# Altium schematic sheet tranciever.SchDoc
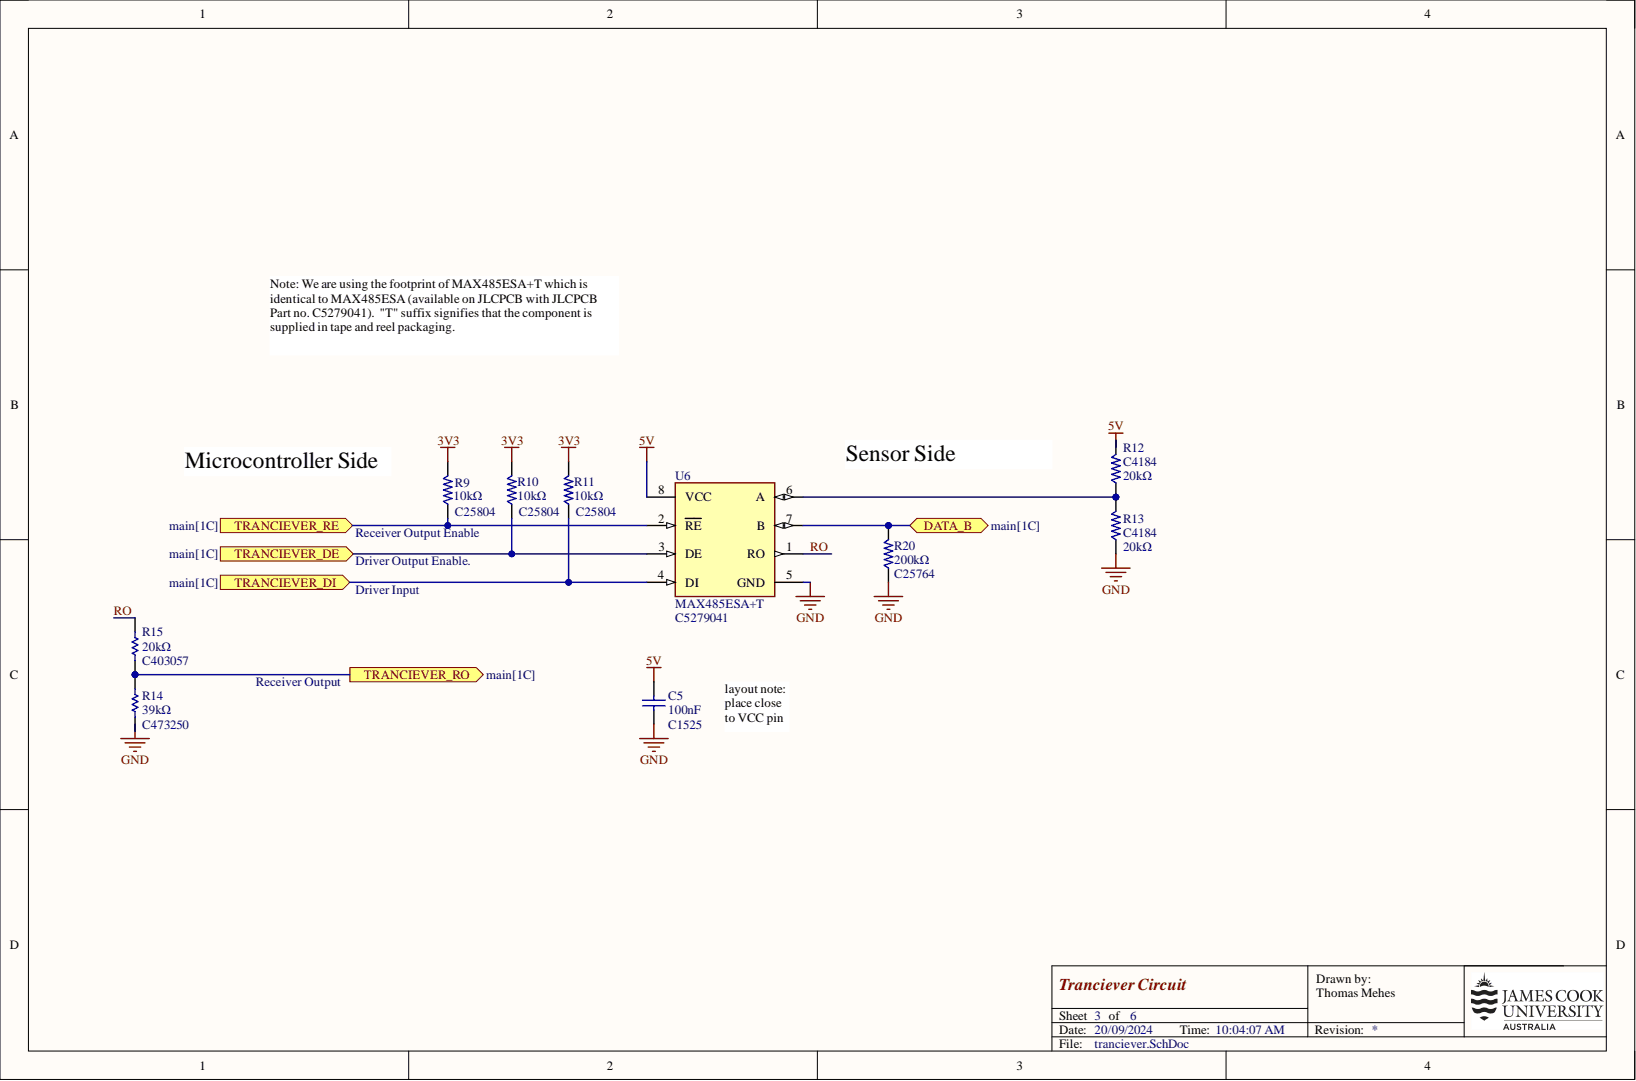
[diagram p0: tranciever.SchDoc]

## Parts
C5  Capacitance: 100nF  JLCPCB Part: C1525  [CAPC1050X55X23NL05T13]  (hidden comment: CL05B104KO5NNNC)
R9  JLCPCB Part: C25804  Resistance: 10kΩ  [RES-0603]  (hidden comment: 0603WAF1002T5E)
R10  JLCPCB Part: C25804  Resistance: 10kΩ  [RES-0603]  (hidden comment: 0603WAF1002T5E)
R11  JLCPCB Part: C25804  Resistance: 10kΩ  [RES-0603]  (hidden comment: 0603WAF1002T5E)
R12  JLCPCB Part: C4184  Resistance: 20kΩ  [RES-0603]  (hidden comment: 0603WAF2002T5E)
R13  JLCPCB Part: C4184  Resistance: 20kΩ  [RES-0603]  (hidden comment: 0603WAF2002T5E)
R14  JLCPCB Part: C473250  Resistance: 39kΩ  [RESC1608X55X30LL20T20]  (hidden comment: ERA-3AEB393V)
R15  JLCPCB Part: C403057  Resistance: 20kΩ  [RESC1608X55X30ML15T15]  (hidden comment: ERJ-3EKF2002V)
R20  JLCPCB Part: C25764  Resistance: 200kΩ  [RES-0402]  (hidden comment: 0402WGF2003TCE)
U6  MAX485ESA+T  JLCPCB Part: C5279041  [FP-S8-2-MFG]

## Ports
DATA_B, TRANCIEVER_DE, TRANCIEVER_DI, TRANCIEVER_RE, TRANCIEVER_RO

## Nets
3V3: R9.2 R10.2 R11.2
5V: C5.2 R12.2 U6.8(VCC)
GND: C5.1 R13.1 R14.1 R20.1 U6.5(GND)
DATA_B: R20.2 U6.7(B) ; port DATA_B
RO: R15.2 U6.1(RO)
TRANCIEVER_DE: R10.1 U6.3(DE) ; port TRANCIEVER_DE
TRANCIEVER_DI: R11.1 U6.4(DI) ; port TRANCIEVER_DI
TRANCIEVER_RE: R9.1 U6.2(RE) ; port TRANCIEVER_RE
TRANCIEVER_RO: R14.2 R15.1 ; port TRANCIEVER_RO
NetR12_1: R12.1 R13.2 U6.6(A)

## Library data of the parts
C5 = CMP-00007-3: Chip Capacitor, 100 nF, +/- 10%, 16 V, -55 to 125 degC, 0402 (1005 Metric), RoHS, Tape and Reel; Manufacturer: Samsung Electro-Mechanics; Dielectric: X7R; Rated Voltage: 16V; Case Size/Dimension: 0402; Component Kind: Standard; Datasheet URL: https://datasheet.ciiva.com/29198/458718-da-01-en-vs-kondensator-0402-29198423.pdf; Datasheet Version: Nov-10; Manufacturer URL: http://www.samsungsem.com/global/index.jsp; Tolerance (%): ±10%; Library Name: James Cook University
R9-R11 = CMP-009-00072-1: Resistor, 10kΩ, ±1%, 100mW, 75V, ±100ppm/℃, -55℃~+155℃, SMD 0603; Manufacturer: Uniroyal; Category: Resistors; Component Kind: Standard; ComponentLink1Description: Datasheet; ComponentLink1URL: https://datasheet.lcsc.com/lcsc/2206010045_UNI-ROYAL-Uniroyal-Elec-0603WAF1002T5E_C25804.pdf; ComponentLink2Description: JLCPCB Part URL; ComponentLink2URL: https://jlcpcb.com/partdetail/C25804; Design Item ID: 0603WAF1002T5E; JLCPCB Basic Part: Yes; Manufacturer Part Number: 0603WAF1002T5E; Package Size: 0603; Power Rating: 100mW; Source: jlcpcb_resistors_basic.schlib; Temperature Coefficient: ±100ppm/℃; Temperature Rating: -55℃~+155℃; Tolerance: ±1%; Voltage Rating: 75V; Library Name: James Cook University
R12 R13 = CMP-009-00100-1: Resistor, 20kΩ, ±1%, 100mW, 75V, ±100ppm/℃, -55℃~+155℃, SMD 0603; Manufacturer: Uniroyal; Category: Resistors; Component Kind: Standard; ComponentLink1Description: Datasheet; ComponentLink1URL: https://datasheet.lcsc.com/lcsc/2206010230_UNI-ROYAL-Uniroyal-Elec-0603WAF2002T5E_C4184.pdf; ComponentLink2Description: JLCPCB Part URL; ComponentLink2URL: https://jlcpcb.com/partdetail/C4184; Design Item ID: 0603WAF2002T5E; JLCPCB Basic Part: Yes; Manufacturer Part Number: 0603WAF2002T5E; Package Size: 0603; Power Rating: 100mW; Source: jlcpcb_resistors_basic.schlib; Temperature Coefficient: ±100ppm/℃; Temperature Rating: -55℃~+155℃; Tolerance: ±1%; Voltage Rating: 75V; Library Name: James Cook University
  R12: JLCPCB Part Number: C4184
R14 = CMP-2000-00055-1: Manufacturer: Panasonic; Component Kind: Standard; Library Name: Altium Content Vault; Part Number: ERA-3AEB393V
R15 = CMP-2000-00318-1: Manufacturer: Panasonic; Component Kind: Standard; Library Name: Altium Content Vault; Part Number: ERJ-3EKF2002V
R20 = CMP-009-00025-1: Resistor, 200kΩ, ±1%, 62.5mW, 50V, ±100ppm/℃, -55℃~+155℃, SMD 0402; Manufacturer: Uniroyal; Category: Resistors; Component Kind: Standard; ComponentLink1Description: Datasheet; ComponentLink1URL: https://datasheet.lcsc.com/lcsc/2206010100_UNI-ROYAL-Uniroyal-Elec-0402WGF2003TCE_C25764.pdf; ComponentLink2Description: JLCPCB Part URL; ComponentLink2URL: https://jlcpcb.com/partdetail/C25764; Design Item ID: 0402WGF2003TCE; JLCPCB Basic Part: Yes; Manufacturer Part Number: 0402WGF2003TCE; Package Size: 0402; Power Rating: 62.5mW; Source: jlcpcb_resistors_basic.schlib; Temperature Coefficient: ±100ppm/℃; Temperature Rating: -55℃~+155℃; Tolerance: ±1%; Voltage Rating: 50V; Library Name: James Cook University
U6 = CMP-05679-000009-1: IC TRANSCEIVER HALF 1/1 8SOIC; Manufacturer: Maxim Integrated; Data Rate: 2.5Mbits/s; Interface: RS-485,RS-422; Max Supply Voltage: 5.25V; Min Supply Voltage: 4.75V; Nominal Supply Current: 0.5mA; Number Of Receivers: 1; Simplex Duplex: Half-Duplex; Standoff Height: 0.1mm; Automotive: No; Category: IC; Component Kind: Standard; ComponentLink2Description: Datasheet; ComponentLink2URL: https://datasheets.maximintegrated.com/en/ds/MAX1487-MAX491.pdf; Device Class L1: Integrated Circuits (ICs); Device Class L2: Interface ICs; Device Class L3: RS-232 / RS-422 / RS-485 Interface ICs; Digikey Description: IC TRANSCEIVER HALF 1/1 8SOIC; Footprint Url: https://pdfserv.maximintegrated.com/land_patterns/90-0096.PDF; Height: 1.75mm; Ipc Land Pattern Name: SOIC127P600X155-8; Lead Free: Yes; Library Name: Altium Content Vault; Manufacturer Part Number: MAX485ESA+T; Mouser Description: RS-422/RS-485 Interface IC RS-485/RS-422 Transceiver; Number Of Transmitters: 1; Octopart: https://octopart.com/max485esa%2Bt-maxim+integrated-40011239; Package: S8-2; RoHS: TRUE; Temperature: -40°C to +85°C; Temperature Range High: +85°C; Temperature Range Low: -40°C
